# Altium schematic sheet 06_LVDS_LCD.SchDoc(06_LVDS_LCD)
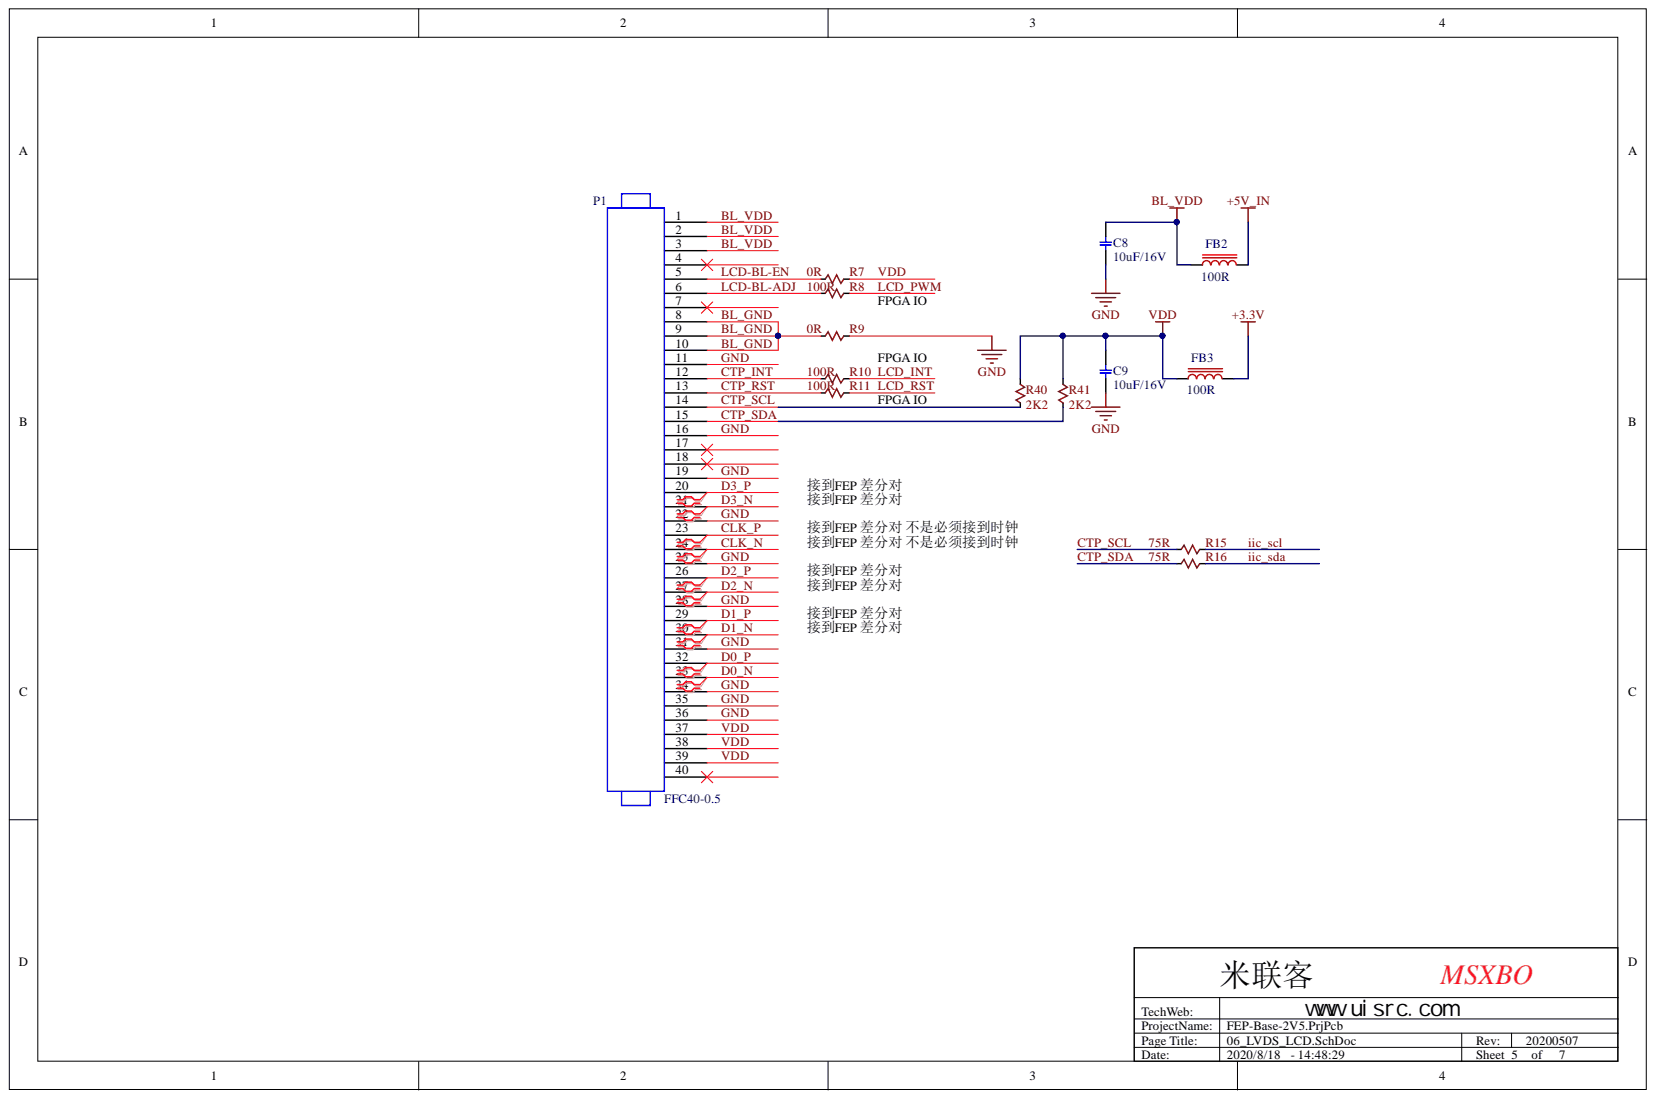
[diagram p0: 06_LVDS_LCD.SchDoc]

## Parts
C8  10uF/16V  [cap0805]
C9  10uF/16V  [cap0805]
FB2  100R  [CAP0603]
FB3  100R  [CAP0603]
P1  FFC40-0.5  [FFC40-0.5]
R7  0R  [RES0402]
R8  100R  [RES0402]
R9  0R  [RES0402]
R10  100R  [RES0402]
R11  100R  [RES0402]
R15  75R  [RES0402]
R16  75R  [RES0402]
R40  2K2  [RES0402]
R41  2K2  [RES0402]

## Nets
+3.3V: FB3.2
+5V_IN: FB2.2
BL_GND: P1.8 P1.9 P1.10 R9.1
BL_VDD: C8.2 FB2.1 P1.1 P1.2 P1.3
CLK_N: P1.24
CLK_P: P1.23
CTP_INT: P1.12 R10.1
CTP_RST: P1.13 R11.1
CTP_SCL: P1.14 R15.1 R40.1
CTP_SDA: P1.15 R16.1 R41.1
D0_N: P1.33
D0_P: P1.32
D1_N: P1.30
D1_P: P1.29
D2_N: P1.27
D2_P: P1.26
D3_N: P1.21
D3_P: P1.20
GND: C8.1 C9.1 P1.11 P1.16 P1.19 P1.22 P1.25 P1.28 P1.31 P1.34 P1.35 P1.36 R9.2
IIC_SCL: R15.2
IIC_SDA: R16.2
LCD-BL-ADJ: P1.6 R8.1
LCD-BL-EN: P1.5 R7.1
LCD_INT: R10.2
LCD_PWM: R8.2
LCD_RST: R11.2
VDD: C9.2 FB3.1 P1.37 P1.38 P1.39 R7.2 R40.2 R41.2

Not connected: P1 4 7 17 18 40

## Library data of the parts
C8 C9 = CAP_10uF/16V: Capacitor; Component Kind: Standard; Library Name: EnHom Frequently Used.SchLib
FB2 FB3 = Ferrite Bead: Generic Ferrite Bead; Value: 600; Component Kind: Standard; Library Name: Miz702N_fun.IntLib
R7-R11 R15 R16 = RESISTOR: Generic Resistor; Value: 390; Component Kind: Standard
  R7-R11: Library Name: Miz702N_fun.IntLib
  R15 R16: Library Name: Miz702fun_20160501.IntLib
R40 R41 = RESISTOR: Generic Resistor; Component Kind: Standard; Library Name: Digilent.IntLib; Value: 2K2
P1 = FFC40-0.5: Component Kind: Standard; Library Name: Miz702N_fun.IntLib
